# Altium schematic sheet Z22 - Relay Platform.SchDoc
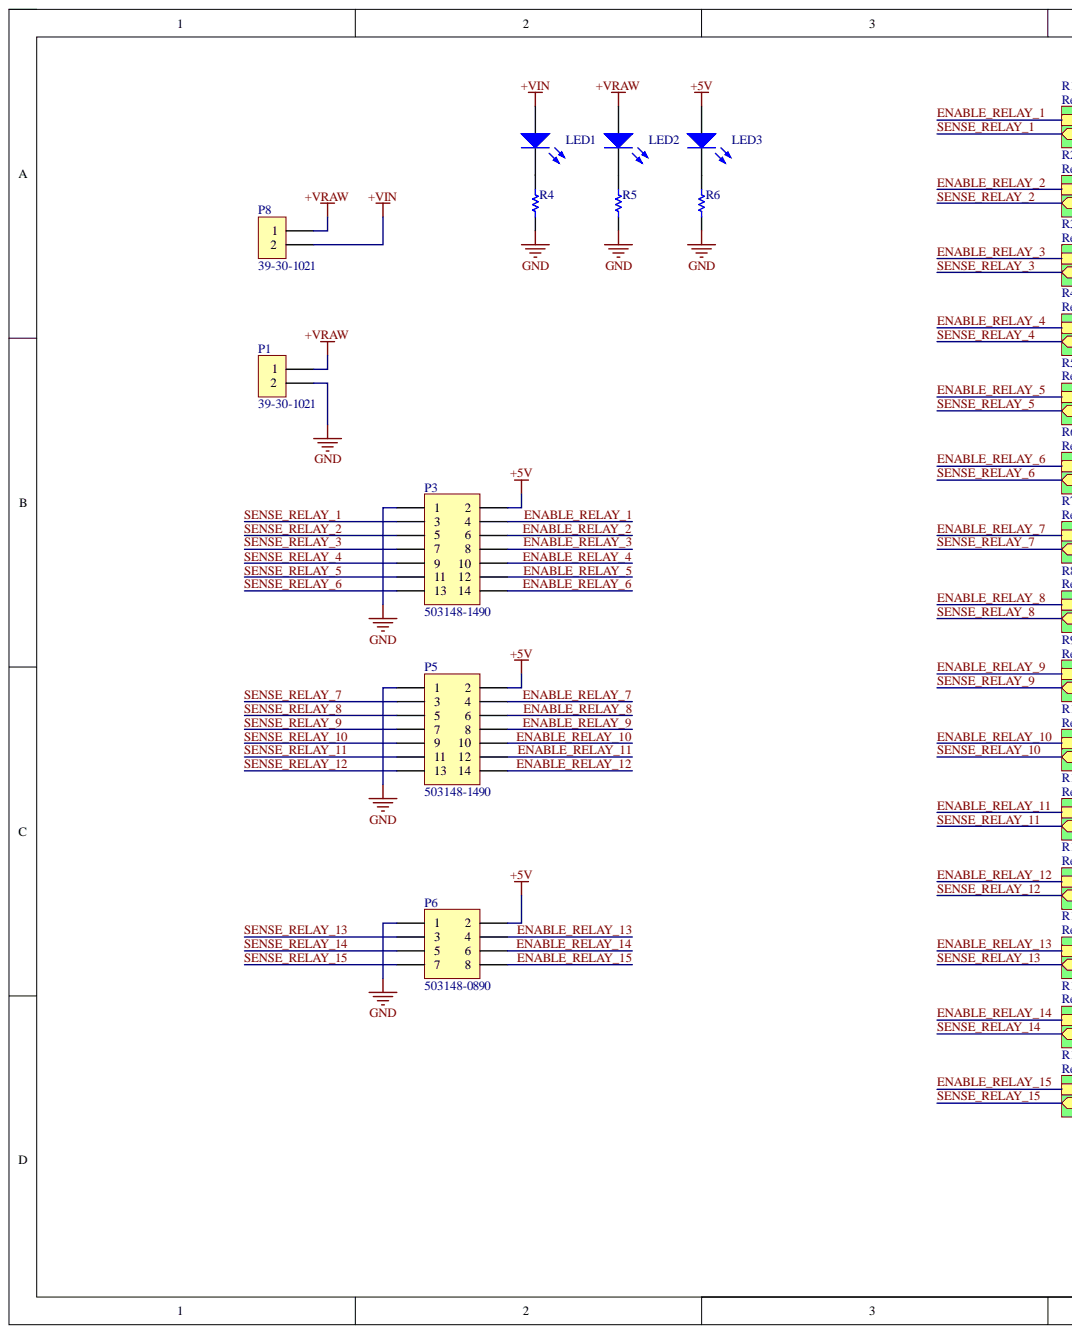
[diagram p0: Z22 - Relay Platform.SchDoc, left]
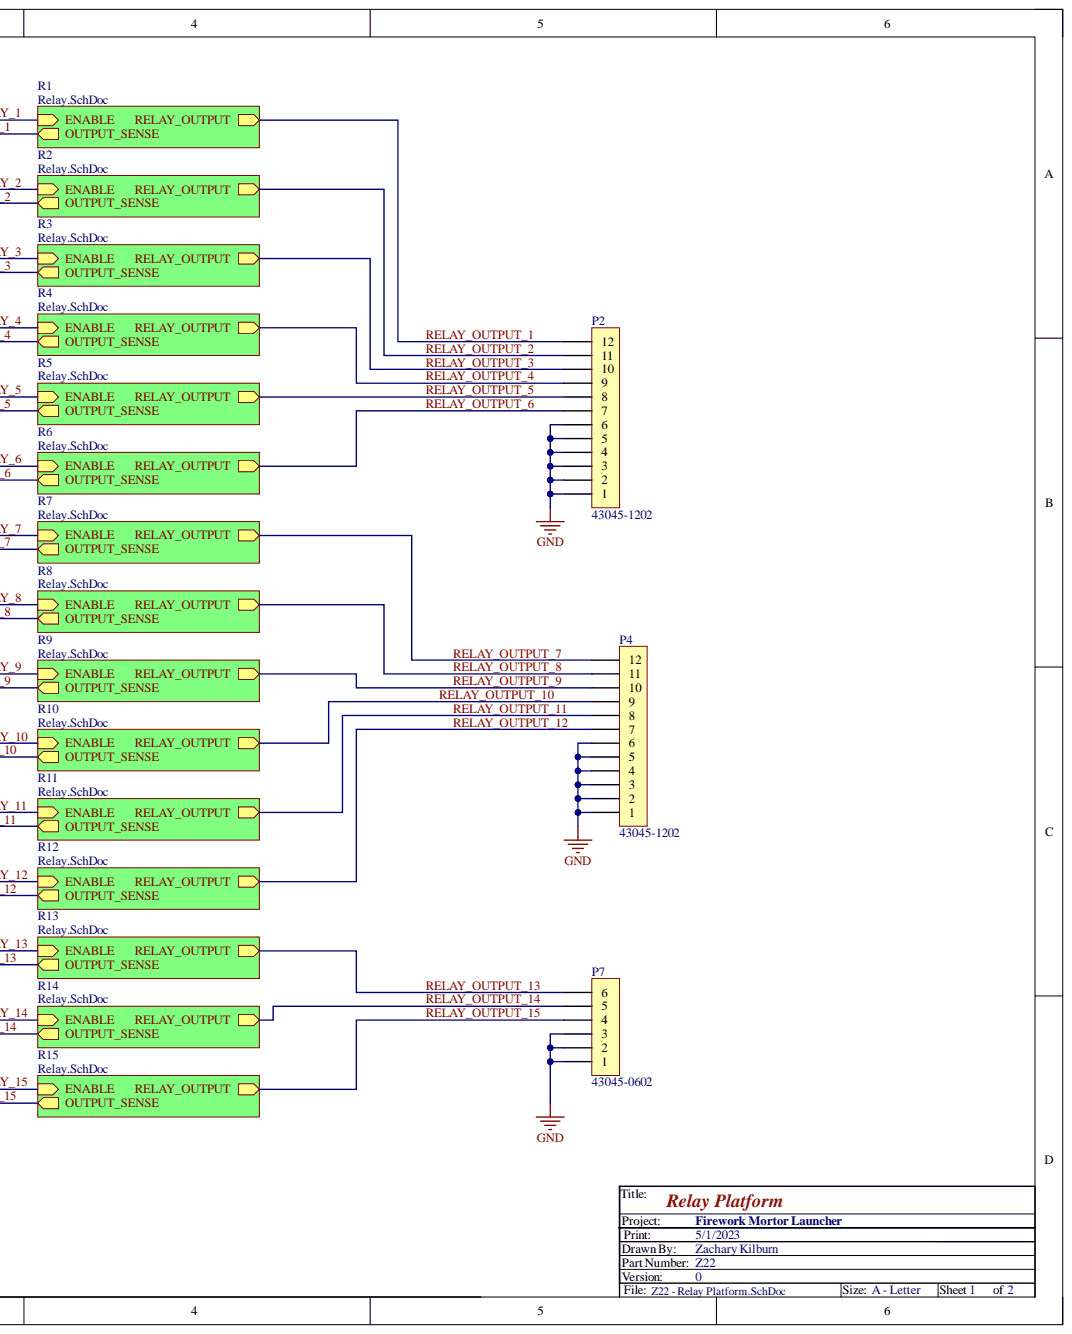
[diagram p1: Z22 - Relay Platform.SchDoc, right]

## Parts
LED1  150060SS75000  [0603_A]  (value not drawn)
LED2  150060SS75000  [0603_A]  (value not drawn)
LED3  150060SS75000  [0603_A]  (value not drawn)
P1  39-30-1021  [PCC-MOLX-5569-HORZ-PEG-02L-2X1-R]
P2  43045-1202  [PCC-MOLX-43045-1202-R]
P3  503148-1490  [PCC-MOLX-503148-1490-R]
P4  43045-1202  [PCC-MOLX-43045-1202-R]
P5  503148-1490  [PCC-MOLX-503148-1490-R]
P6  503148-0890  [PCC-MOLX-503148-0890-R]
P7  43045-0602  [PCC-MOLX-43045-0602-R]
P8  39-30-1021  [PCC-MOLX-5569-HORZ-PEG-02L-2X1-R]
R4  ERA3AEB104V  [RESC1608X55X30ML20T20]  (value not drawn)
R5  ERA3AEB104V  [RESC1608X55X30ML20T20]  (value not drawn)
R6  ERA3AEB104V  [RESC1608X55X30ML20T20]  (value not drawn)

## Nets
+5V: LED3.2 P3.2 P5.2 P6.2
+VIN: LED1.2 P8.2
+VRAW: LED2.2 P1.1 P8.1
GND: P1.2 P2.1 P2.2 P2.3 P2.4 P2.5 P2.6 P3.1 P4.1 P4.2 P4.3 P4.4 P4.5 P4.6 P5.1 P6.1 P7.1 P7.2 P7.3 R4.1 R5.1 R6.1
ENABLE_RELAY_1: P3.4
ENABLE_RELAY_2: P3.6
ENABLE_RELAY_3: P3.8
ENABLE_RELAY_4: P3.10
ENABLE_RELAY_5: P3.12
ENABLE_RELAY_6: P3.14
ENABLE_RELAY_7: P5.4
ENABLE_RELAY_8: P5.6
ENABLE_RELAY_9: P5.8
ENABLE_RELAY_10: P5.10
ENABLE_RELAY_11: P5.12
ENABLE_RELAY_12: P5.14
ENABLE_RELAY_13: P6.4
ENABLE_RELAY_14: P6.6
ENABLE_RELAY_15: P6.8
RELAY_OUTPUT_1: P2.12
RELAY_OUTPUT_2: P2.11
RELAY_OUTPUT_3: P2.10
RELAY_OUTPUT_4: P2.9
RELAY_OUTPUT_5: P2.8
RELAY_OUTPUT_6: P2.7
RELAY_OUTPUT_7: P4.12
RELAY_OUTPUT_8: P4.11
RELAY_OUTPUT_9: P4.10
RELAY_OUTPUT_10: P4.9
RELAY_OUTPUT_11: P4.8
RELAY_OUTPUT_12: P4.7
RELAY_OUTPUT_13: P7.6
RELAY_OUTPUT_14: P7.5
RELAY_OUTPUT_15: P7.4
SENSE_RELAY_1: P3.3
SENSE_RELAY_2: P3.5
SENSE_RELAY_3: P3.7
SENSE_RELAY_4: P3.9
SENSE_RELAY_5: P3.11
SENSE_RELAY_6: P3.13
SENSE_RELAY_7: P5.3
SENSE_RELAY_8: P5.5
SENSE_RELAY_9: P5.7
SENSE_RELAY_10: P5.9
SENSE_RELAY_11: P5.11
SENSE_RELAY_12: P5.13
SENSE_RELAY_13: P6.3
SENSE_RELAY_14: P6.5
SENSE_RELAY_15: P6.7
NetLED1_1: LED1.1 R4.2
NetLED2_1: LED2.1 R5.2
NetLED3_1: LED3.1 R6.2

## Library data of the parts
LED1-LED3 = CMP-1426-00004-1: SMD mono-color Chip LED, WL-SMCW, Super Red; Manufacturer: Wuerth Elektronik; Color: Super Red; Component Kind: Standard; ComponentLink1Description: Manufacturer URL; ComponentLink1URL: http://www.we-online.com; ComponentLink2Description: Datasheet; ComponentLink2URL: http://katalog.we-online.com/led/datasheet/150060SS75000.pdf; Library Name: Altium Content Vault; PackageReference: 0603_A; PartNumber: 150060SS75000
P1 P8 = CMP-000084-0: Molex Mini-Fit Jr. Connector, Dual-Row, 2-Position, Right Angle, PCB Lock, Gold Plating; Current: 7A; MatingStyle: Plug; Pitch: 4.2mm; Positions: 2; Voltage: 600V; Component Kind: Standard; ComponentLink1Description: Manufacturer URL; ComponentLink1URL: http://www.molex.com; ComponentLink2Description: Product URL; ComponentLink2URL: http://www.molex.com/molex/products/datasheet.jsp?part=active/0039301021_PCB_HEADERS.xml; ComponentLink3Description: Datasheet; ComponentLink3URL: http://www.molex.com/pdm_docs/ps/PS-5556-001.pdf; ComponentLink4Description: Package Specification; ComponentLink4URL: http://www.molex.com/pdm_docs/sd/039301021_sd.pdf; HelpURL: http://www.molex.com/pdm_docs/sd/039301021_sd.pdf; Library Name: Connectors.SchLib; Manufacturer: Molex; MountingTechnology: Through Hole; OperatingTemperature: -40C to +105C; Orientation: Right Angle; PackageName: Mini-Fit Jr.; PartNumber: 39-30-1021; RoHS: Yes; Rows: 2; StorageTemperature: -40C to +105C
P2 P4 = CMP-000195-0: Molex Micro-Fit 3.0 Connector, Dual-Row, 12-Position, Right-Angle, 3mm Pitch; MatingStyle: Plug; Positions: 12; Rows: 2; Voltage: 250V; Component Kind: Standard; ComponentLink1Description: Manufacturer URL; ComponentLink1URL: http://www.molex.com; ComponentLink2Description: Product URL; ComponentLink2URL: http://www.molex.com/molex/products/datasheet.jsp?part=active/0430451202_PCB_HEADERS.xml; ComponentLink3Description: Datasheet; ComponentLink3URL: http://www.molex.com/pdm_docs/ps/PS-43045.pdf; ComponentLink4Description: Package Specification; ComponentLink4URL: http://www.molex.com/pdm_docs/sd/430451202_sd.pdf; HelpURL: http://www.molex.com/pdm_docs/ps/PS-43045.pdf; Library Name: Molex-Micro-Fit.SchLib; Manufacturer: Molex; MountingTechnology: Through Hole; OperatingTemperature: -40C to +105C; Orientation: Right Angle; PackageName: Micro-Fit 3.0; PartNumber: 43045-1202; Pitch: 3mm; RoHS: Yes; StorageTemperature: -40C to +105C
P3 P5 = CMP-000071-0: Molex CLIK-Mate Series Connector, Dual-Row, 14-Position, Right Angle, 1.5mm Pitch; Current: 2A; MatingStyle: Plug; PackingType: Reel; Positions: 14; Rows: 2; Voltage: 100V; Component Kind: Standard; ComponentLink1Description: Manufacturer URL; ComponentLink1URL: http://www.molex.com; ComponentLink2Description: Product URL; ComponentLink2URL: http://www.molex.com/molex/products/datasheet.jsp?part=active/5031481490_PCB_RECEPTACLES.xml; ComponentLink3Description: Datasheet; ComponentLink3URL: http://www.molex.com/pdm_docs/ps/PS-503148-001-001.pdf; ComponentLink4Description: Package Specification; ComponentLink4URL: http://www.molex.com/pdm_docs/sd/5031481490_sd.pdf; HelpURL: http://www.molex.com/pdm_docs/sd/5031481490_sd.pdf; Library Name: Connectors.SchLib; Manufacturer: Molex; MountingTechnology: Surface Mount; OperatingTemperature: -40C to +105C; Orientation: Right Angle; PackageName: CLIK-Mate; PartNumber: 503148-1490; Pitch: 1.5mm; RoHS: Yes; StorageTemperature: +5C to +35C
P6 = CMP-000068-0: Molex CLIK-Mate Series Connector, Dual-Row, 8-Position, Right Angle, 1.5mm Pitch; Current: 2A; MatingStyle: Plug; PackingType: Reel; Positions: 8; Rows: 2; Voltage: 100V; Component Kind: Standard; ComponentLink1Description: Manufacturer URL; ComponentLink1URL: http://www.molex.com; ComponentLink2Description: Product URL; ComponentLink2URL: http://www.molex.com/molex/products/datasheet.jsp?part=active/5031480890_PCB_RECEPTACLES.xml; ComponentLink3Description: Datasheet; ComponentLink3URL: http://www.molex.com/pdm_docs/ps/PS-503148-001-001.pdf; ComponentLink4Description: Package Specification; ComponentLink4URL: http://www.molex.com/pdm_docs/sd/5031480890_sd.pdf; HelpURL: http://www.molex.com/pdm_docs/sd/5031480890_sd.pdf; Library Name: Connectors.SchLib; Manufacturer: Molex; MountingTechnology: Surface Mount; OperatingTemperature: -40C to +105C; Orientation: Right Angle; PackageName: CLIK-Mate; PartNumber: 503148-0890; Pitch: 1.5mm; RoHS: Yes; StorageTemperature: +5C to +35C
P7 = CMP-000192-0: Molex Micro-Fit 3.0 Connector, Dual-Row, 6-Position, Right-Angle, 3mm Pitch; MatingStyle: Plug; Positions: 6; Rows: 2; Voltage: 250V; Component Kind: Standard; ComponentLink1Description: Manufacturer URL; ComponentLink1URL: http://www.molex.com; ComponentLink2Description: Product URL; ComponentLink2URL: http://www.molex.com/molex/products/datasheet.jsp?part=active/0430450602_PCB_HEADERS.xml; ComponentLink3Description: Datasheet; ComponentLink3URL: http://www.molex.com/pdm_docs/ps/PS-43045.pdf; ComponentLink4Description: Package Specification; ComponentLink4URL: http://www.molex.com/pdm_docs/sd/430450602_sd.pdf; HelpURL: http://www.molex.com/pdm_docs/ps/PS-43045.pdf; Library Name: Connectors.SchLib; Manufacturer: Molex; MountingTechnology: Through Hole; OperatingTemperature: -40C to +105C; Orientation: Right Angle; PackageName: Micro-Fit 3.0; PartNumber: 43045-0602; Pitch: 3mm; RoHS: Yes; StorageTemperature: -40C to +105C
R4-R6 = CMP-2000-00875-1: Manufacturer: Panasonic; Component Kind: Standard; Library Name: Altium Content Vault; Part Number: ERA3AEB104V
